# Altium schematic sheet A3-ESP32S3.SchDoc
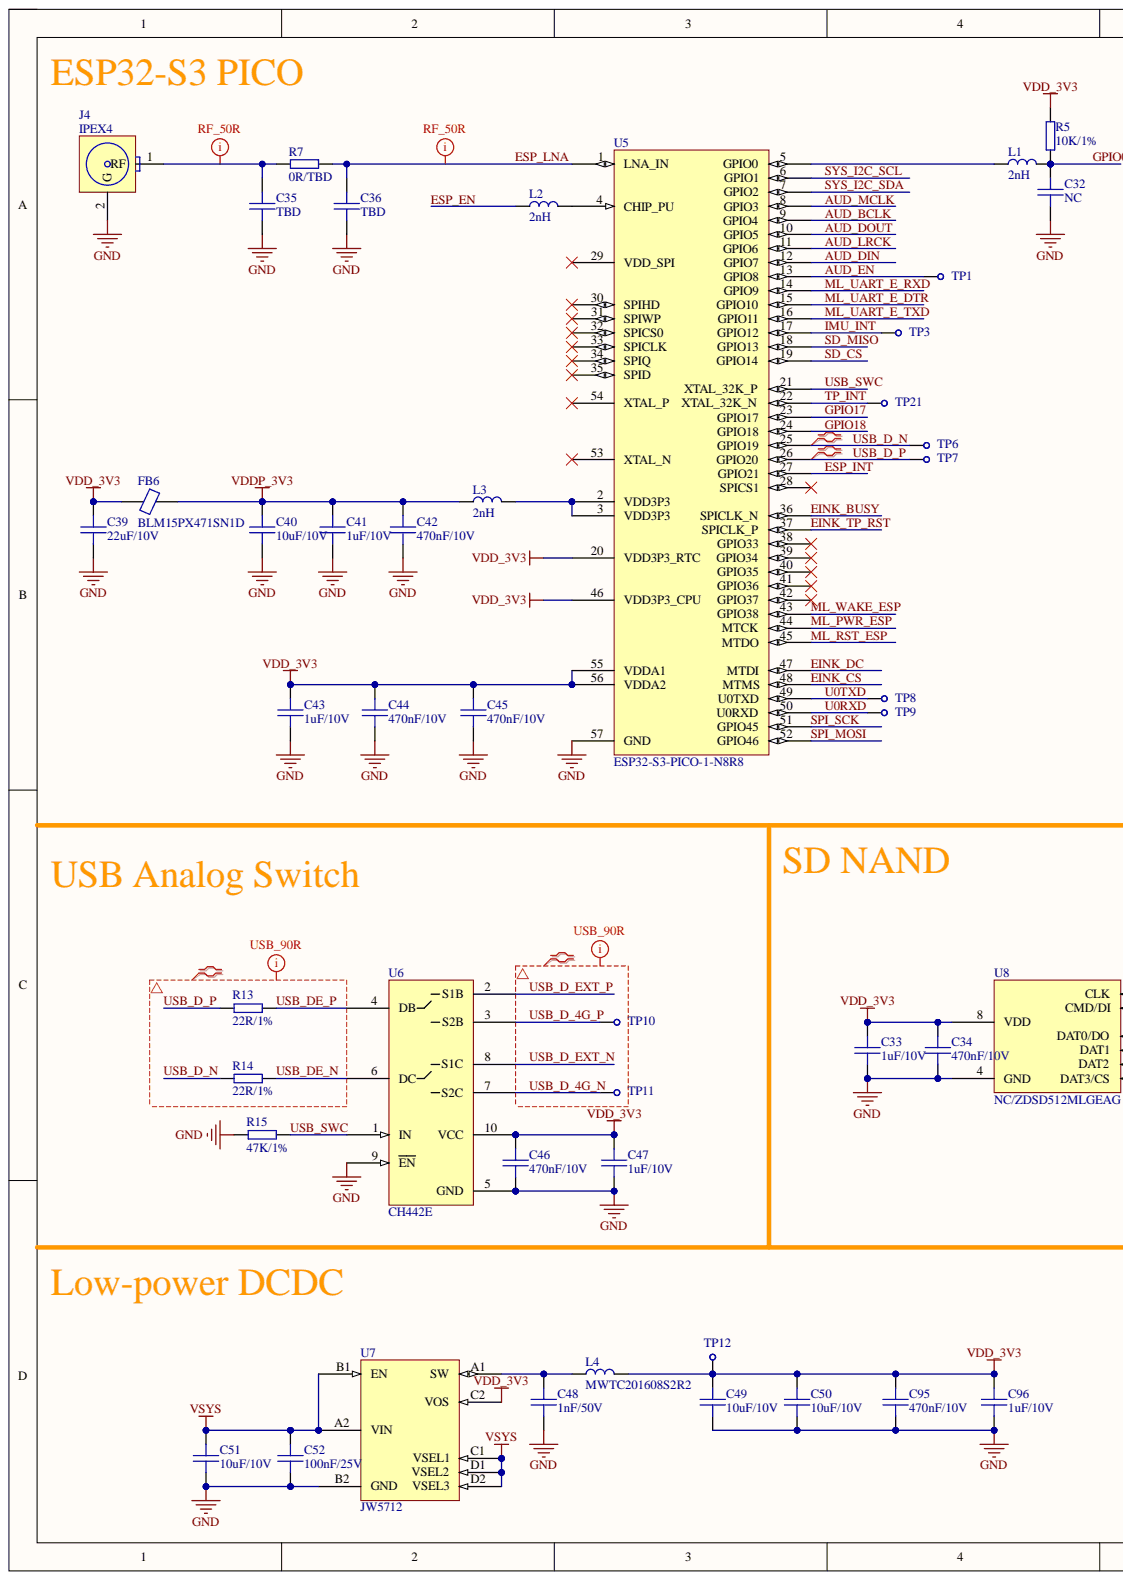
[diagram p0: A3-ESP32S3.SchDoc, left]
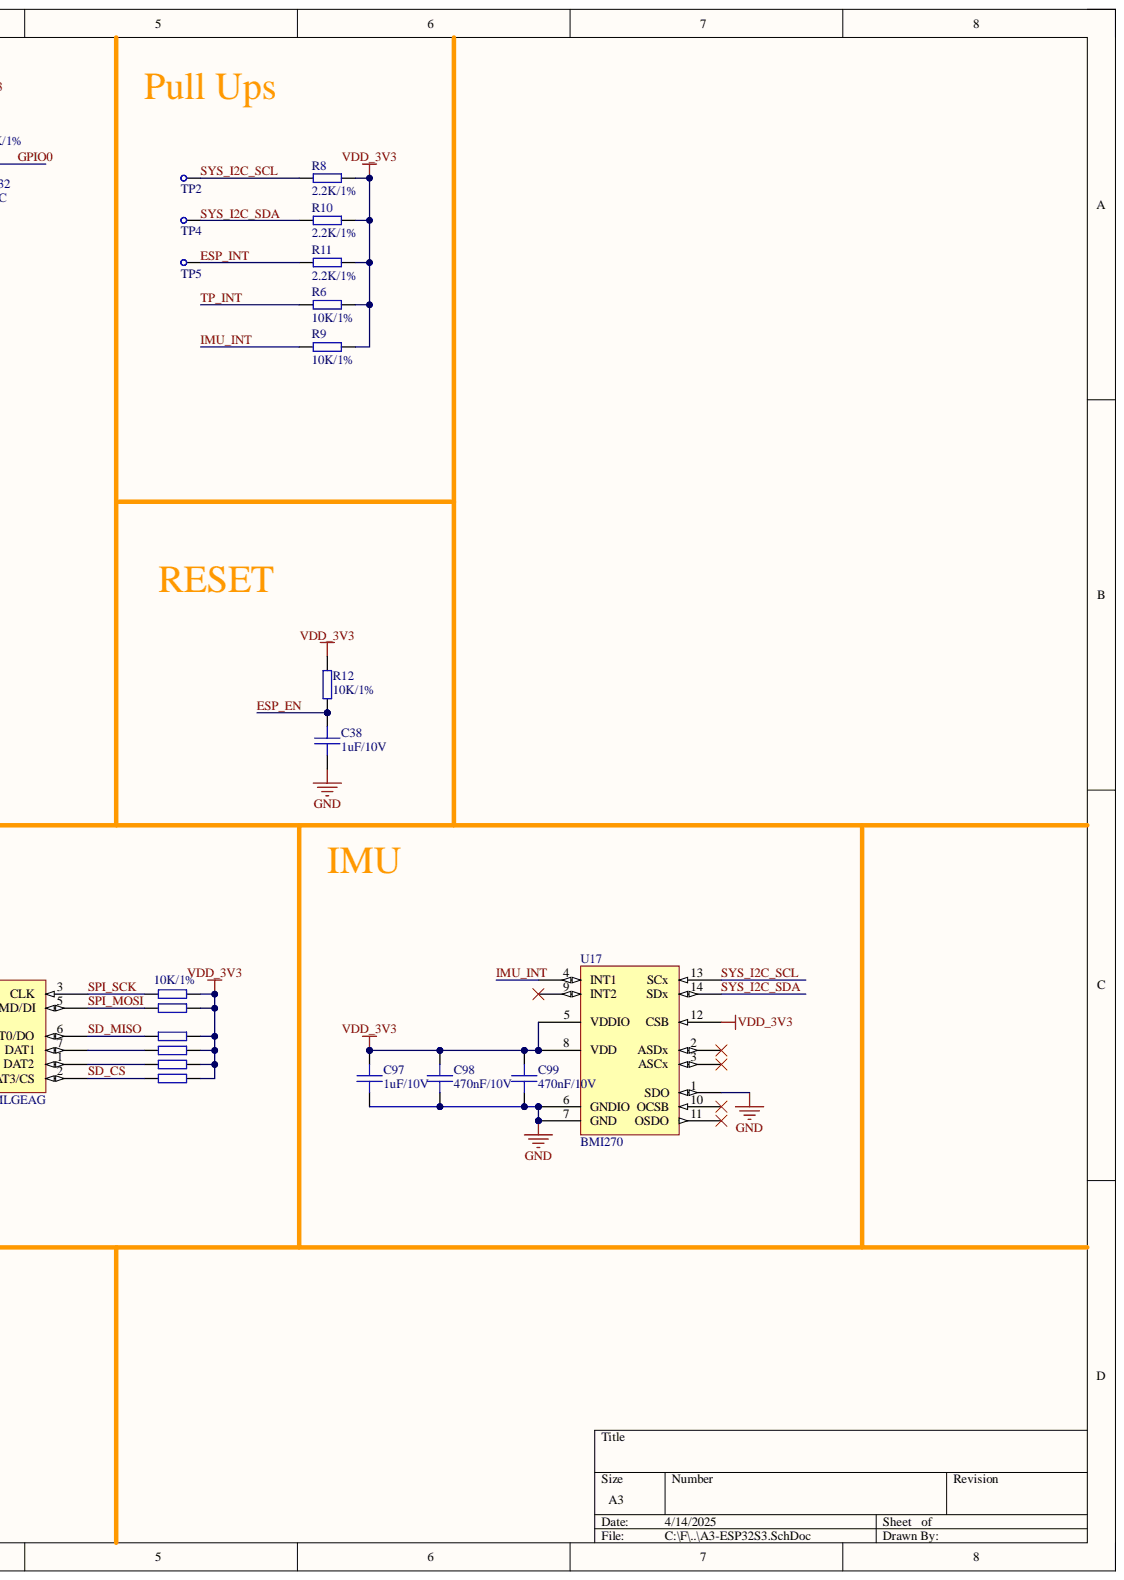
[diagram p1: A3-ESP32S3.SchDoc, right]

## Parts
C32  NC  [C0402-HD]
C33  1uF/10V  [C0201-HD]
C34  470nF/10V  [C0201-HD]
C35  TBD  [C0402-HD]
C36  TBD  [C0402-HD]
C38  1uF/10V  [C0201-HD]
C39  22uF/10V  [C0603-HD]
C40  10uF/10V  [C0402-L-HD]
C41  1uF/10V  [C0201-HD]
C42  470nF/10V  [C0201-HD]
C43  1uF/10V  [C0201-HD]
C44  470nF/10V  [C0201-HD]
C45  470nF/10V  [C0201-HD]
C46  470nF/10V  [C0201-HD]
C47  1uF/10V  [C0201-HD]
C48  1nF/50V  [C0201-HD]
C49  10uF/10V  [C0402-L-HD]
C50  10uF/10V  [C0402-L-HD]
C51  10uF/10V  [C0402-L-HD]
C52  100nF/25V  [C0201-HD]
C95  470nF/10V  [C0201-HD]
C96  1uF/10V  [C0201-HD]
C97  1uF/10V  [C0201-HD]
C98  470nF/10V  [C0201-HD]
C99  470nF/10V  [C0201-HD]
FB6  BLM15PX471SN1D  [L0402-HD]
J4  IPEX4  [IPEX4]
L1  2nH  [L0201-HD]
L2  2nH  [L0201-HD]
L3  2nH  [L0201-HD]
L4  MWTC201608S2R2  [FTC121065S]
R5  10K/1%  [R0402-HD]
R6  10K/1%  [R0402-HD]
R7  0R/TBD  [C0402-HD]
R8  2.2K/1%  [R0402-HD]
R9  10K/1%  [R0402-HD]
R10  2.2K/1%  [R0402-HD]
R11  2.2K/1%  [R0402-HD]
R12  10K/1%  [R0402-HD]
R13  22R/1%  [R0402-HD]
R14  22R/1%  [R0402-HD]
R15  47K/1%  [R0402-HD]
R24  10K/1%  [R0402-HD]
R42  10K/1%  [R0402-HD]
R43  10K/1%  [R0402-HD]  (value not drawn)
R44  10K/1%  [R0402-HD]  (value not drawn)
R45  10K/1%  [R0402-HD]  (value not drawn)
R46  10K/1%  [R0402-HD]  (value not drawn)
TP1  SMT_TEST_POINT  [SMT_TEST_POINT]  (value not drawn)
TP2  SMT_TEST_POINT  [SMT_TEST_POINT]  (value not drawn)
TP3  SMT_TEST_POINT  [SMT_TEST_POINT]  (value not drawn)
TP4  SMT_TEST_POINT  [SMT_TEST_POINT]  (value not drawn)
TP5  SMT_TEST_POINT  [SMT_TEST_POINT]  (value not drawn)
TP6  SMT_TEST_POINT  [SMT_TEST_POINT]  (value not drawn)
TP7  SMT_TEST_POINT  [SMT_TEST_POINT]  (value not drawn)
TP8  SMT_TEST_POINT  [SMT_TEST_POINT]  (value not drawn)
TP9  SMT_TEST_POINT  [SMT_TEST_POINT]  (value not drawn)
TP10  SMT_TEST_POINT  [SMT_TEST_POINT]  (value not drawn)
TP11  SMT_TEST_POINT  [SMT_TEST_POINT]  (value not drawn)
TP12  SMT_TEST_POINT  [SMT_TEST_POINT]  (value not drawn)
TP21  SMT_TEST_POINT  [SMT_TEST_POINT]  (value not drawn)
U5  ESP32-S3-PICO-1-N8R8  [QFN40P700X700X90_HS-57N]
U6  CH442E  [TSSOP50P490X101-10N]
U7  JW5712  [BGA8C40P2X4_86X156X60]
U8  NC/ZDSD512MLGEAG  [SD_NAND_LGA8_8X6_H0.85]
U17  BMI270  [BMI270]

## Nets
GND: C32.2 C33.2 C34.1 C35.1 C36.1 C38.2 C39.2 C40.1 C41.2 C42.1 C43.2 C44.1 C45.1 C46.1 C47.2 C48.1 C49.1 C50.1 C51.1 C52.1 C95.1 C96.2 C97.2 C98.1 C99.1 J4.2 R15.1 U5.57(GND) U6.5(GND) U6.9(EN) U7.B2(GND) U8.4(GND) U17.1(SDO) U17.6(GNDIO) U17.7(GND)
VDD_3V3: C33.1 C34.2 C39.1 C43.1 C44.2 C45.2 C46.2 C47.1 C49.2 C50.2 C95.2 C96.1 C97.1 C98.2 C99.2 FB6.2 L4.1 R5.2 R6.2 R8.2 R9.2 R10.2 R11.2 R12.2 R24.1 R42.1 R43.1 R44.1 R45.1 R46.1 TP12.1 U5.20(VDD3P3_RTC) U5.46(VDD3P3_CPU) U5.55(VDDA1) U5.56(VDDA2) U6.10(VCC) U7.C2(VOS) U8.8(VDD) U17.5(VDDIO) U17.8(VDD) U17.12(CSB)
VDDP_3V3: C40.2 C41.1 C42.2 FB6.1 L3.2
VSYS: C51.2 C52.2 U7.A2(VIN) U7.B1(EN) U7.C1(VSEL1) U7.D1(VSEL2) U7.D2(VSEL3)
AUD_BCLK: U5.9(GPIO4)
AUD_DIN: U5.12(GPIO7)
AUD_DOUT: U5.10(GPIO5)
AUD_EN: TP1.1 U5.13(GPIO8)
AUD_LRCK: U5.11(GPIO6)
AUD_MCLK: U5.8(GPIO3)
EINK_BUSY: U5.36(SPICLK_N)
EINK_CS: U5.48(MTMS)
EINK_DC: U5.47(MTDI)
EINK_TP_RST: U5.37(SPICLK_P)
ESP_EN: C38.1 L2.2 R12.1
ESP_INT: R11.1 TP5.1 U5.27(GPIO21)
ESP_LNA: C36.2 R7.2 U5.1(LNA_IN)
GPIO0: C32.1 L1.1 R5.1
GPIO17: U5.23(GPIO17)
GPIO18: U5.24(GPIO18)
IMU_INT: R9.1 TP3.1 U5.17(GPIO12) U17.4(INT1)
ML_PWR_ESP: U5.44(MTCK)
ML_RST_ESP: U5.45(MTDO)
ML_UART_E_DTR: U5.15(GPIO10)
ML_UART_E_RXD: U5.14(GPIO9)
ML_UART_E_TXD: U5.16(GPIO11)
ML_WAKE_ESP: U5.43(GPIO38)
SD_CS: R46.2 U5.19(GPIO14) U8.2(DAT3/CS)
SD_MISO: R43.2 U5.18(GPIO13) U8.6(DAT0/DO)
SPI_MOSI: R42.2 U5.52(GPIO46) U8.5(CMD/DI)
SPI_SCK: R24.2 U5.51(GPIO45) U8.3(CLK)
SYS_I2C_SCL: R8.1 TP2.1 U5.6(GPIO1) U17.13(SCx)
SYS_I2C_SDA: R10.1 TP4.1 U5.7(GPIO2) U17.14(SDx)
TP_INT: R6.1 TP21.1 U5.22(XTAL_32K_N)
U0RXD: TP9.1 U5.50(U0RXD)
U0TXD: TP8.1 U5.49(U0TXD)
USB_D_4G_N: TP11.1 U6.7(S2C)
USB_D_4G_P: TP10.1 U6.3(S2B)
USB_D_EXT_N: U6.8(S1C)
USB_D_EXT_P: U6.2(S1B)
USB_D_N: R14.2 TP6.1 U5.25(GPIO19)
USB_D_P: R13.2 TP7.1 U5.26(GPIO20)
USB_DE_N: R14.1 U6.6(DC)
USB_DE_P: R13.1 U6.4(DB)
USB_SWC: R15.2 U5.21(XTAL_32K_P) U6.1(IN)
NetC35_2: C35.2 J4.1 R7.1
NetC48_2: C48.2 L4.2 U7.A1(SW)
NetL1_2: L1.2 U5.5(GPIO0)
NetL2_1: L2.1 U5.4(CHIP_PU)
NetL3_1: L3.1 U5.2(VDD3P3) U5.3(VDD3P3)
NetR44_2: R44.2 U8.7(DAT1)
NetR45_2: R45.2 U8.1(DAT2)

Not connected: U5 28(SPICS1) 29(VDD_SPI) 30(SPIHD) 31(SPIWP) 32(SPICS0) 33(SPICLK) 34(SPIQ) 35(SPID) 38(GPIO33) 39(GPIO34) 40(GPIO35) 41(GPIO36) 42(GPIO37) 53(XTAL_N) 54(XTAL_P); U17 2(ASDx) 3(ASCx) 9(INT2) 10(OCSB) 11(OSDO)

## Library data of the parts
C32-C36 C38 C40-C52 C95-C99 = SMT_C_0402: Surface mount capacitor 0402; Component Kind: Standard; Library Name: Vowstar_Basic_Device.SchLib
C39 = SMT_C_0603: Surface mount capacitor 0603; Component Kind: Standard; Library Name: Vowstar_Basic_Device.SchLib
FB6 = SMT_FB_0402: Surface mount ferrite beads 0402; Component Kind: Standard; Library Name: Vowstar_Basic_Device.SchLib
J4 = IPEX: IPEX RF connector; Component Kind: Standard; Library Name: Vowstar_Connector.SchLib
L1-L3 = SMT_L_0201: Surface mount inductor 0201; Component Kind: Standard; Library Name: Vowstar_Basic_Device.SchLib
L4 = SMT_L_0805: Surface mount inductor 0805; Component Kind: Standard; Library Name: Vowstar_Basic_Device.SchLib
R5-R15 R24 R42-R46 = SMT_R_0402: Surface mount resistor 0402; Component Kind: Standard; Library Name: Vowstar_Basic_Device.SchLib
TP1-TP12 TP21 = SMT_TEST_POINT: SMT Test Point; Component Kind: Standard; Library Name: Vowstar_Basic_Device.SchLib
U5 = ESP32-S3: Component Kind: Standard; Library Name: Espressif-CHIP.SchLib
U8 = SD_NAND: Component Kind: Standard; Library Name: GENERAL-IC.SchLib
U6 = CH442E: Component Kind: Standard; Library Name: GENERAL-IC.SchLib
U7 = JW5712: Component Kind: Standard; Library Name: GENERAL-IC.SchLib
U17 = BMI270: Component Kind: Standard; Library Name: GENERAL-IC.SchLib
